# Altium schematic sheet A2-AUDIO.SchDoc
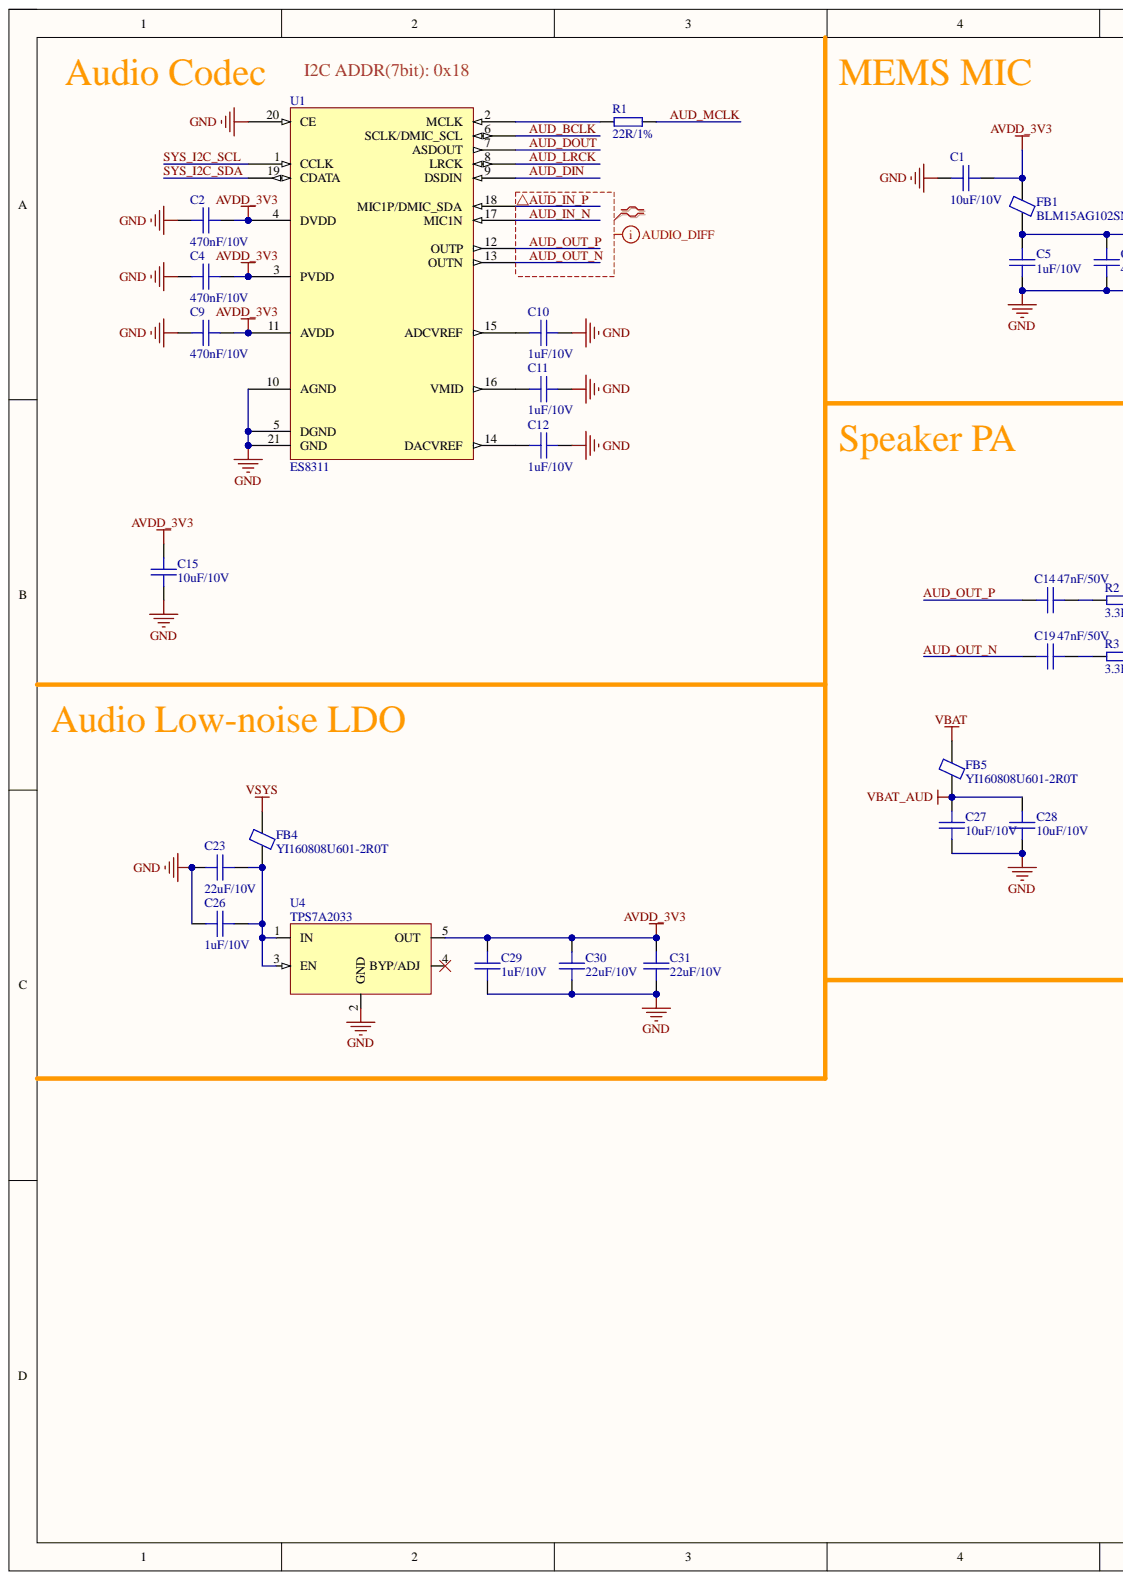
[diagram p0: A2-AUDIO.SchDoc, left]
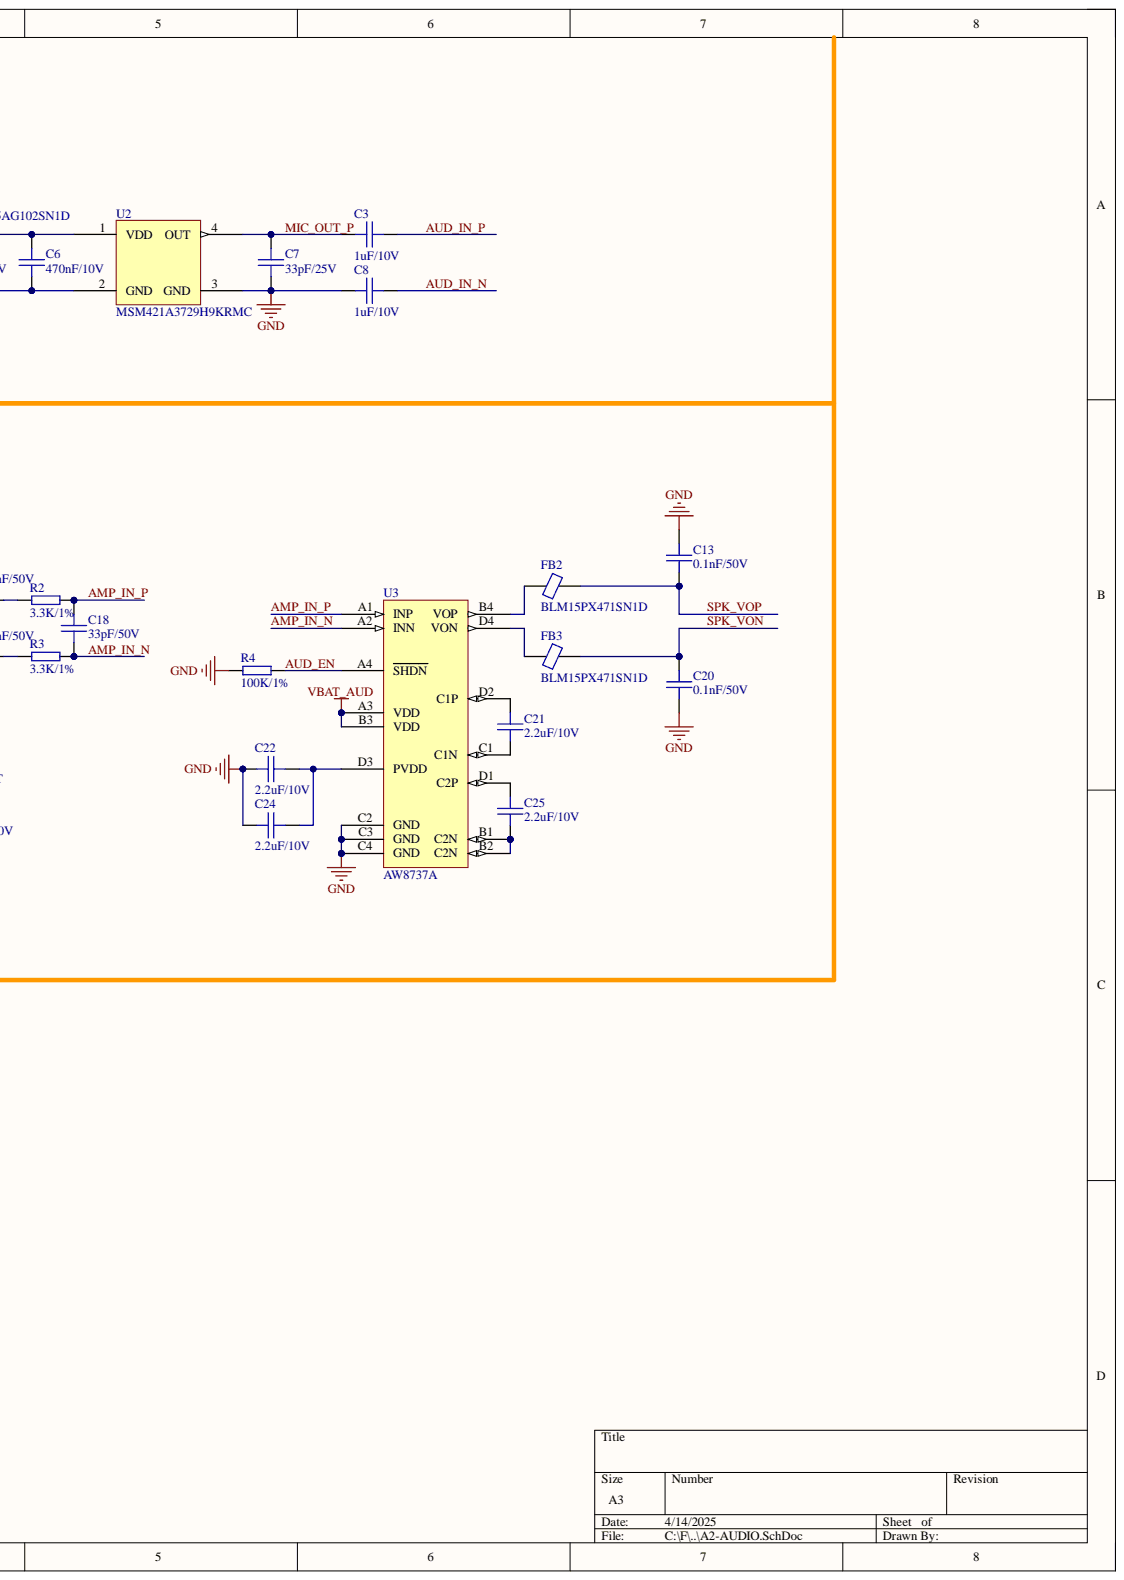
[diagram p1: A2-AUDIO.SchDoc, right]

## Parts
C1  10uF/10V  [C0402-L-HD]
C2  470nF/10V  [C0201-HD]
C3  1uF/10V  [C0201-HD]
C4  470nF/10V  [C0201-HD]
C5  1uF/10V  [C0201-HD]
C6  470nF/10V  [C0201-HD]
C7  33pF/25V  [C0201-HD]
C8  1uF/10V  [C0201-HD]
C9  470nF/10V  [C0201-HD]
C10  1uF/10V  [C0201-HD]
C11  1uF/10V  [C0201-HD]
C12  1uF/10V  [C0201-HD]
C13  0.1nF/50V  [C0402-HD]
C14  47nF/50V  [C0201-HD]
C15  10uF/10V  [C0402-L-HD]
C18  33pF/50V  [C0201-HD]
C19  47nF/50V  [C0201-HD]
C20  0.1nF/50V  [C0402-HD]
C21  2.2uF/10V  [C0402-HD]
C22  2.2uF/10V  [C0402-HD]
C23  22uF/10V  [C0603-HD]
C24  2.2uF/10V  [C0402-HD]
C25  2.2uF/10V  [C0402-HD]
C26  1uF/10V  [C0201-HD]
C27  10uF/10V  [C0402-L-HD]
C28  10uF/10V  [C0402-L-HD]
C29  1uF/10V  [C0201-HD]
C30  22uF/10V  [C0603-HD]
C31  22uF/10V  [C0603-HD]
FB1  BLM15AG102SN1D  [L0402-HD]
FB2  BLM15PX471SN1D  [L0402-HD]
FB3  BLM15PX471SN1D  [L0402-HD]
FB4  YI160808U601-2R0T  [L0603-HD]
FB5  YI160808U601-2R0T  [L0603-HD]
R1  22R/1%  [R0402-HD]
R2  3.3K/1%  [R0402-HD]
R3  3.3K/1%  [R0402-HD]
R4  100K/1%  [R0402-HD]
U1  ES8311  [QFN40P300X300X60_HS-21L]
U2  MSM421A3729H9KRMC  [MSM381A3729H9BPC]
U3  AW8737A  [AW8737A]
U4  TPS7A2033  [SOT-235-HD]

## Nets
AVDD_3V3: C1.1 C2.2 C4.2 C9.2 C15.2 C29.1 C30.1 C31.1 FB1.1 U1.3(PVDD) U1.4(DVDD) U1.11(AVDD) U4.5(OUT)
GND: C1.2 C2.1 C4.1 C5.1 C6.1 C7.2 C8.1 C9.1 C10.2 C11.2 C12.2 C13.2 C15.1 C20.2 C22.1 C23.1 C24.1 C26.1 C27.2 C28.2 C29.2 C30.2 C31.2 R4.2 U1.5(DGND) U1.10(AGND) U1.20(CE) U1.21(GND) U2.2(GND) U2.3(GND) U3.C2(GND) U3.C3(GND) U3.C4(GND) U4.2(GND)
VBAT: FB5.1
VBAT_AUD: C27.1 C28.1 FB5.2 U3.A3(VDD) U3.B3(VDD)
VSYS: FB4.1
AMP_IN_N: C18.2 R3.2 U3.A2(INN)
AMP_IN_P: C18.1 R2.2 U3.A1(INP)
AUD_BCLK: U1.6(SCLK/DMIC_SCL)
AUD_DIN: U1.9(DSDIN)
AUD_DOUT: U1.7(ASDOUT)
AUD_EN: R4.1 U3.A4(SHDN)
AUD_IN_N: C8.2 U1.17(MIC1N)
AUD_IN_P: C3.2 U1.18(MIC1P/DMIC_SDA)
AUD_LRCK: U1.8(LRCK)
AUD_MCLK: R1.2
AUD_OUT_N: C19.2 U1.13(OUTN)
AUD_OUT_P: C14.2 U1.12(OUTP)
MIC_OUT_P: C3.1 C7.1 U2.4(OUT)
SPK_VON: C20.1 FB3.1
SPK_VOP: C13.1 FB2.1
SYS_I2C_SCL: U1.1(CCLK)
SYS_I2C_SDA: U1.19(CDATA)
NetC5_2: C5.2 C6.2 FB1.2 U2.1(VDD)
NetC10_1: C10.1 U1.15(ADCVREF)
NetC11_1: C11.1 U1.16(VMID)
NetC12_1: C12.1 U1.14(DACVREF)
NetC14_1: C14.1 R2.1
NetC19_1: C19.1 R3.1
NetC21_1: C21.1 U3.D2(C1P)
NetC21_2: C21.2 U3.C1(C1N)
NetC22_2: C22.2 C24.2 U3.D3(PVDD)
NetC23_2: C23.2 C26.2 FB4.2 U4.1(IN) U4.3(EN)
NetC25_1: C25.1 U3.D1(C2P)
NetC25_2: C25.2 U3.B1(C2N) U3.B2(C2N)
NetFB2_2: FB2.2 U3.B4(VOP)
NetFB3_2: FB3.2 U3.D4(VON)
NetR1_1: R1.1 U1.2(MCLK)

Not connected: U4 4(BYP/ADJ)

## Library data of the parts
C1-C15 C18-C22 C24-C29 = SMT_C_0402: Surface mount capacitor 0402; Component Kind: Standard; Library Name: Vowstar_Basic_Device.SchLib
C23 C30 C31 = SMT_C_0603: Surface mount capacitor 0603; Component Kind: Standard; Library Name: Vowstar_Basic_Device.SchLib
FB1-FB3 = SMT_FB_0402: Surface mount ferrite beads 0402; Component Kind: Standard; Library Name: Vowstar_Basic_Device.SchLib
FB4 FB5 = SMT_FB_0603: Surface mount ferrite beads 0603; Component Kind: Standard; Library Name: Vowstar_Basic_Device.SchLib
R1-R4 = SMT_R_0402: Surface mount resistor 0402; Component Kind: Standard; Library Name: Vowstar_Basic_Device.SchLib
U2 = MSM381A3729H9BPC: Component Kind: Standard; Library Name: MEMS-MICs.SchLib
U4 = SPX3819: 500mA, Low-Noise LDO Voltage Regulator; Component Kind: Standard; Library Name: Vowstar_IC_General.SchLib
U1 = ES8311: Component Kind: Standard; Library Name: GENERAL-IC.SchLib
U3 = AW8737A: Component Kind: Standard; Library Name: GENERAL-IC.SchLib
